# Altium schematic sheet KeyInput.SchDoc(KeyInput)
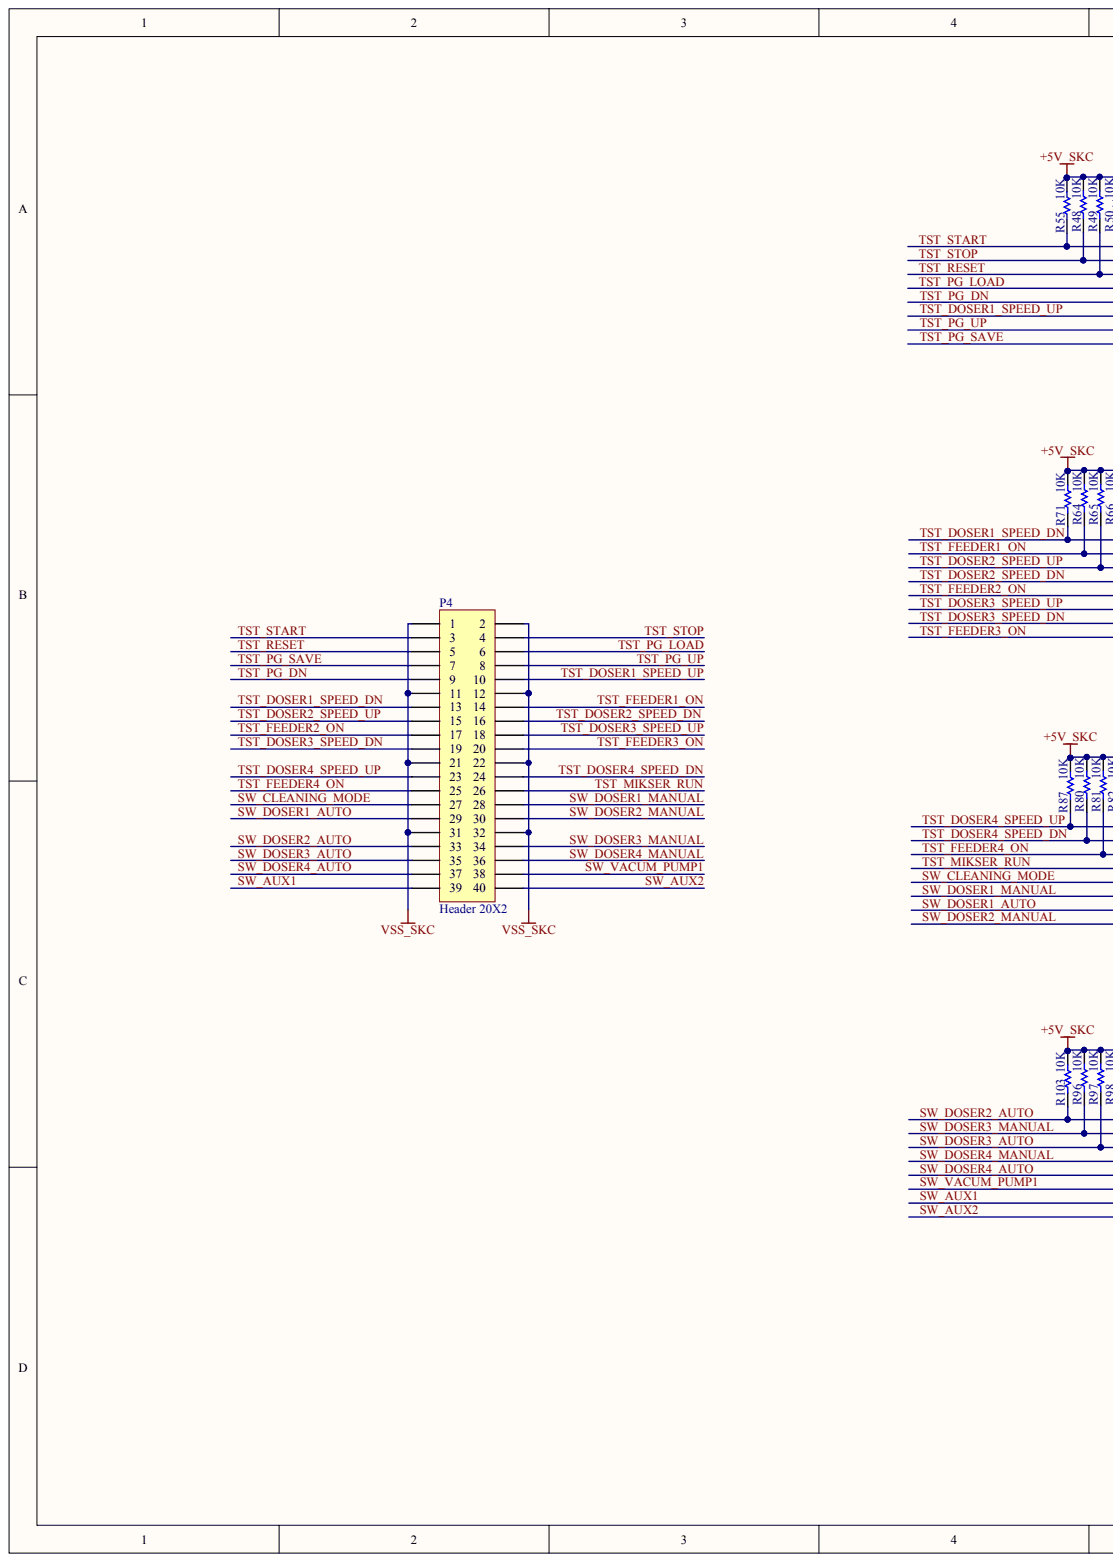
[diagram p0: KeyInput.SchDoc, left]
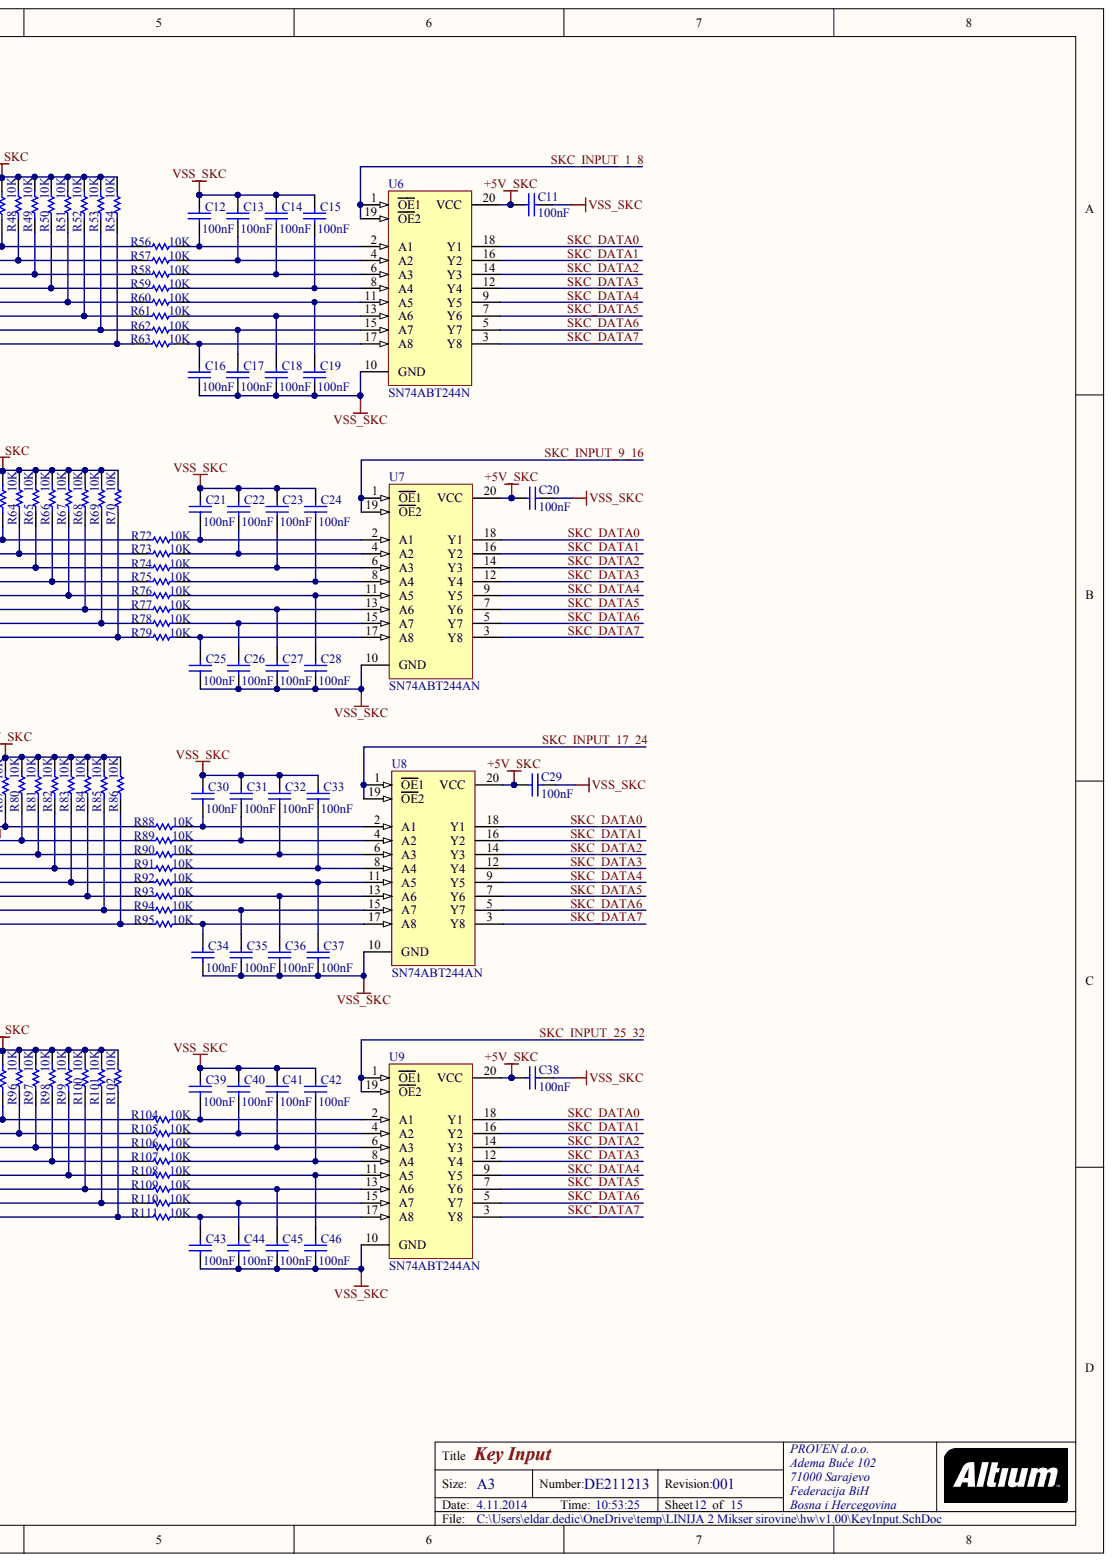
[diagram p1: KeyInput.SchDoc, right]

## Parts
C11  value 100nF
C12  value 100nF
C13  value 100nF
C14  value 100nF
C15  value 100nF
C16  value 100nF
C17  value 100nF
C18  value 100nF
C19  value 100nF
C20  value 100nF
C21  value 100nF
C22  value 100nF
C23  value 100nF
C24  value 100nF
C25  value 100nF
C26  value 100nF
C27  value 100nF
C28  value 100nF
C29  value 100nF
C30  value 100nF
C31  value 100nF
C32  value 100nF
C33  value 100nF
C34  value 100nF
C35  value 100nF
C36  value 100nF
C37  value 100nF
C38  value 100nF
C39  value 100nF
C40  value 100nF
C41  value 100nF
C42  value 100nF
C43  value 100nF
C44  value 100nF
C45  value 100nF
C46  value 100nF
P4  Header 20X2
R48  10K
R49  10K
R50  10K
R51  10K
R52  10K
R53  10K
R54  10K
R55  10K
R56  10K
R57  10K
R58  10K
R59  10K
R60  10K
R61  10K
R62  10K
R63  10K
R64  10K
R65  10K
R66  10K
R67  10K
R68  10K
R69  10K
R70  10K
R71  10K
R72  10K
R73  10K
R74  10K
R75  10K
R76  10K
R77  10K
R78  10K
R79  10K
R80  10K
R81  10K
R82  10K
R83  10K
R84  10K
R85  10K
R86  10K
R87  10K
R88  10K
R89  10K
R90  10K
R91  10K
R92  10K
R93  10K
R94  10K
R95  10K
R96  10K
R97  10K
R98  10K
R99  10K
R100  10K
R101  10K
R102  10K
R103  10K
R104  10K
R105  10K
R106  10K
R107  10K
R108  10K
R109  10K
R110  10K
R111  10K
U6  SN74ABT244N
U7  SN74ABT244AN
U8  SN74ABT244AN
U9  SN74ABT244AN

## Nets
+5V_SKC: C11.1 C20.1 C29.1 C38.1 R48.2 R49.2 R50.2 R51.2 R52.2 R53.2 R54.2 R55.2 R64.2 R65.2 R66.2 R67.2 R68.2 R69.2 R70.2 R71.2 R80.2 R81.2 R82.2 R83.2 R84.2 R85.2 R86.2 R87.2 R96.2 R97.2 R98.2 R99.2 R100.2 R101.2 R102.2 R103.2 U6.20(VCC) U7.20(VCC) U8.20(VCC) U9.20(VCC)
VSS_SKC: C11.2 C12.2 C13.2 C14.2 C15.2 C16.1 C17.1 C18.1 C19.1 C20.2 C21.2 C22.2 C23.2 C24.2 C25.1 C26.1 C27.1 C28.1 C29.2 C30.2 C31.2 C32.2 C33.2 C34.1 C35.1 C36.1 C37.1 C38.2 C39.2 C40.2 C41.2 C42.2 C43.1 C44.1 C45.1 C46.1 P4.1 P4.2 P4.11 P4.12 P4.21 P4.22 P4.31 P4.32 U6.10(GND) U7.10(GND) U8.10(GND) U9.10(GND)
SKC_DATA0: U6.18(Y1) U7.18(Y1) U8.18(Y1) U9.18(Y1)
SKC_DATA1: U6.16(Y2) U7.16(Y2) U8.16(Y2) U9.16(Y2)
SKC_DATA2: U6.14(Y3) U7.14(Y3) U8.14(Y3) U9.14(Y3)
SKC_DATA3: U6.12(Y4) U7.12(Y4) U8.12(Y4) U9.12(Y4)
SKC_DATA4: U6.9(Y5) U7.9(Y5) U8.9(Y5) U9.9(Y5)
SKC_DATA5: U6.7(Y6) U7.7(Y6) U8.7(Y6) U9.7(Y6)
SKC_DATA6: U6.5(Y7) U7.5(Y7) U8.5(Y7) U9.5(Y7)
SKC_DATA7: U6.3(Y8) U7.3(Y8) U8.3(Y8) U9.3(Y8)
SKC_INPUT_1_8: U6.1(OE1) U6.19(OE2)
SKC_INPUT_9_16: U7.1(OE1) U7.19(OE2)
SKC_INPUT_17_24: U8.1(OE1) U8.19(OE2)
SKC_INPUT_25_32: U9.1(OE1) U9.19(OE2)
SW_AUX1: P4.39 R101.1 R110.1
SW_AUX2: P4.40 R102.1 R111.1
SW_CLEANING_MODE: P4.27 R83.1 R92.1
SW_DOSER1_AUTO: P4.29 R85.1 R94.1
SW_DOSER1_MANUAL: P4.28 R84.1 R93.1
SW_DOSER2_AUTO: P4.33 R103.1 R104.1
SW_DOSER2_MANUAL: P4.30 R86.1 R95.1
SW_DOSER3_AUTO: P4.35 R97.1 R106.1
SW_DOSER3_MANUAL: P4.34 R96.1 R105.1
SW_DOSER4_AUTO: P4.37 R99.1 R108.1
SW_DOSER4_MANUAL: P4.36 R98.1 R107.1
SW_VACUM_PUMP1: P4.38 R100.1 R109.1
TST_DOSER1_SPEED_DN: P4.13 R71.1 R72.1
TST_DOSER1_SPEED_UP: P4.10 R52.1 R61.1
TST_DOSER2_SPEED_DN: P4.16 R66.1 R75.1
TST_DOSER2_SPEED_UP: P4.15 R65.1 R74.1
TST_DOSER3_SPEED_DN: P4.19 R69.1 R78.1
TST_DOSER3_SPEED_UP: P4.18 R68.1 R77.1
TST_DOSER4_SPEED_DN: P4.24 R80.1 R89.1
TST_DOSER4_SPEED_UP: P4.23 R87.1 R88.1
TST_FEEDER1_ON: P4.14 R64.1 R73.1
TST_FEEDER2_ON: P4.17 R67.1 R76.1
TST_FEEDER3_ON: P4.20 R70.1 R79.1
TST_FEEDER4_ON: P4.25 R81.1 R90.1
TST_MIKSER_RUN: P4.26 R82.1 R91.1
TST_PG_DN: P4.9 R51.1 R60.1
TST_PG_LOAD: P4.6 R50.1 R59.1
TST_PG_SAVE: P4.7 R54.1 R63.1
TST_PG_UP: P4.8 R53.1 R62.1
TST_RESET: P4.5 R49.1 R58.1
TST_START: P4.3 R55.1 R56.1
TST_STOP: P4.4 R48.1 R57.1
NetC12_1: C12.1 R56.2 U6.2(A1)
NetC13_1: C13.1 R57.2 U6.4(A2)
NetC14_1: C14.1 R58.2 U6.6(A3)
NetC15_1: C15.1 R59.2 U6.8(A4)
NetC16_2: C16.2 R63.2 U6.17(A8)
NetC17_2: C17.2 R62.2 U6.15(A7)
NetC18_2: C18.2 R61.2 U6.13(A6)
NetC19_2: C19.2 R60.2 U6.11(A5)
NetC21_1: C21.1 R72.2 U7.2(A1)
NetC22_1: C22.1 R73.2 U7.4(A2)
NetC23_1: C23.1 R74.2 U7.6(A3)
NetC24_1: C24.1 R75.2 U7.8(A4)
NetC25_2: C25.2 R79.2 U7.17(A8)
NetC26_2: C26.2 R78.2 U7.15(A7)
NetC27_2: C27.2 R77.2 U7.13(A6)
NetC28_2: C28.2 R76.2 U7.11(A5)
NetC30_1: C30.1 R88.2 U8.2(A1)
NetC31_1: C31.1 R89.2 U8.4(A2)
NetC32_1: C32.1 R90.2 U8.6(A3)
NetC33_1: C33.1 R91.2 U8.8(A4)
NetC34_2: C34.2 R95.2 U8.17(A8)
NetC35_2: C35.2 R94.2 U8.15(A7)
NetC36_2: C36.2 R93.2 U8.13(A6)
NetC37_2: C37.2 R92.2 U8.11(A5)
NetC39_1: C39.1 R104.2 U9.2(A1)
NetC40_1: C40.1 R105.2 U9.4(A2)
NetC41_1: C41.1 R106.2 U9.6(A3)
NetC42_1: C42.1 R107.2 U9.8(A4)
NetC43_2: C43.2 R111.2 U9.17(A8)
NetC44_2: C44.2 R110.2 U9.15(A7)
NetC45_2: C45.2 R109.2 U9.13(A6)
NetC46_2: C46.2 R108.2 U9.11(A5)

## Library data of the parts
R48-R111 = (no symbol name): Note: If flow soldering, adjust pad width of PCB Footprint to 0.7 - 0.8 times the width of the resistor.; Published: 17-Dec-2003; LatestRevisionDate: 19-Mar-2004; LatestRevisionNote: Silkscreen size reduced.; PackageDocument: Mar-2003; PackageReference: ERA-0805; Code_IPC: 2012 [0805]; ComponentLink1Description: Manufacturer Link; ComponentLink1URL: http://www.panasonic.com/industrial/components/resistive_products.htm; ComponentLink2Description: Datasheet; ComponentLink2URL: http://www.panasonic.com/industrial/components/pdf/AOA0000CE8.pdf; Publisher: Altium Limited
U6 = (no symbol name): ComponentAvailability: Obsolete; Published: 8-Jun-2000; LatestRevisionDate: 06-Jun-2005; LatestRevisionNote: Stylized 3D Model Added.; DatasheetVersion: Jul-1994; PackageVersion: Oct-1995; PackageReference: N020; PackageDescription: DIP; 20 Leads; Row Spacing 7.62 mm; Pitch 2.54 mm; ComponentLink1Description: Manufacturer Link; ComponentLink1URL: http://www.ti.com/; ComponentLink2Description: Discontinuation Notice; ComponentLink2URL: http://focus.ti.com/docs/prod/folders/print/sn74abt244.html; Publisher: Altium Limited
C11-C46 P4 U7-U9 = (no symbol name): Publisher: Altium Limited
  C11-C46: Published: 17-Jul-2002; LatestRevisionDate: 19-Mar-2004; LatestRevisionNote: Silkscreen size reduced.; DatasheetDocument: Mar-2001; PackageDocument: Mar-2001; PackageReference: 1206; Code_JEITA: 1206; Code_IPC: 3216 [1206]; ComponentLink1Description: Manufacturer Link; ComponentLink1URL: http://www.kemet.com/; ComponentLink2Description: Datasheet; ComponentLink2URL: http://www.kemet.com/kemet/web/homepage/kechome.nsf/vapubfilesname/F3102TA.pdf/$file/F3102TA.pdf
  P4: LatestRevisionDate: 17-Jul-2002; LatestRevisionNote: Re-released for DXP Platform.
  U7-U9: Published: 8-Jun-2000; LatestRevisionDate: 06-Jun-2005; LatestRevisionNote: Stylized 3D Model Added.; DatasheetVersion: Jan-1997; PackageVersion: Oct-1995; PackageReference: N020; PackageDescription: DIP; 20 Leads; Row Spacing 7.62 mm; Pitch 2.54 mm; ComponentLink1Description: Manufacturer Link; ComponentLink1URL: http://www.ti.com/; ComponentLink2Description: Datasheet; ComponentLink2URL: http://www-s.ti.com/sc/ds/sn74abt244a.pdf
